# Altium schematic sheet Sheet1.SchDoc(Sheet1)
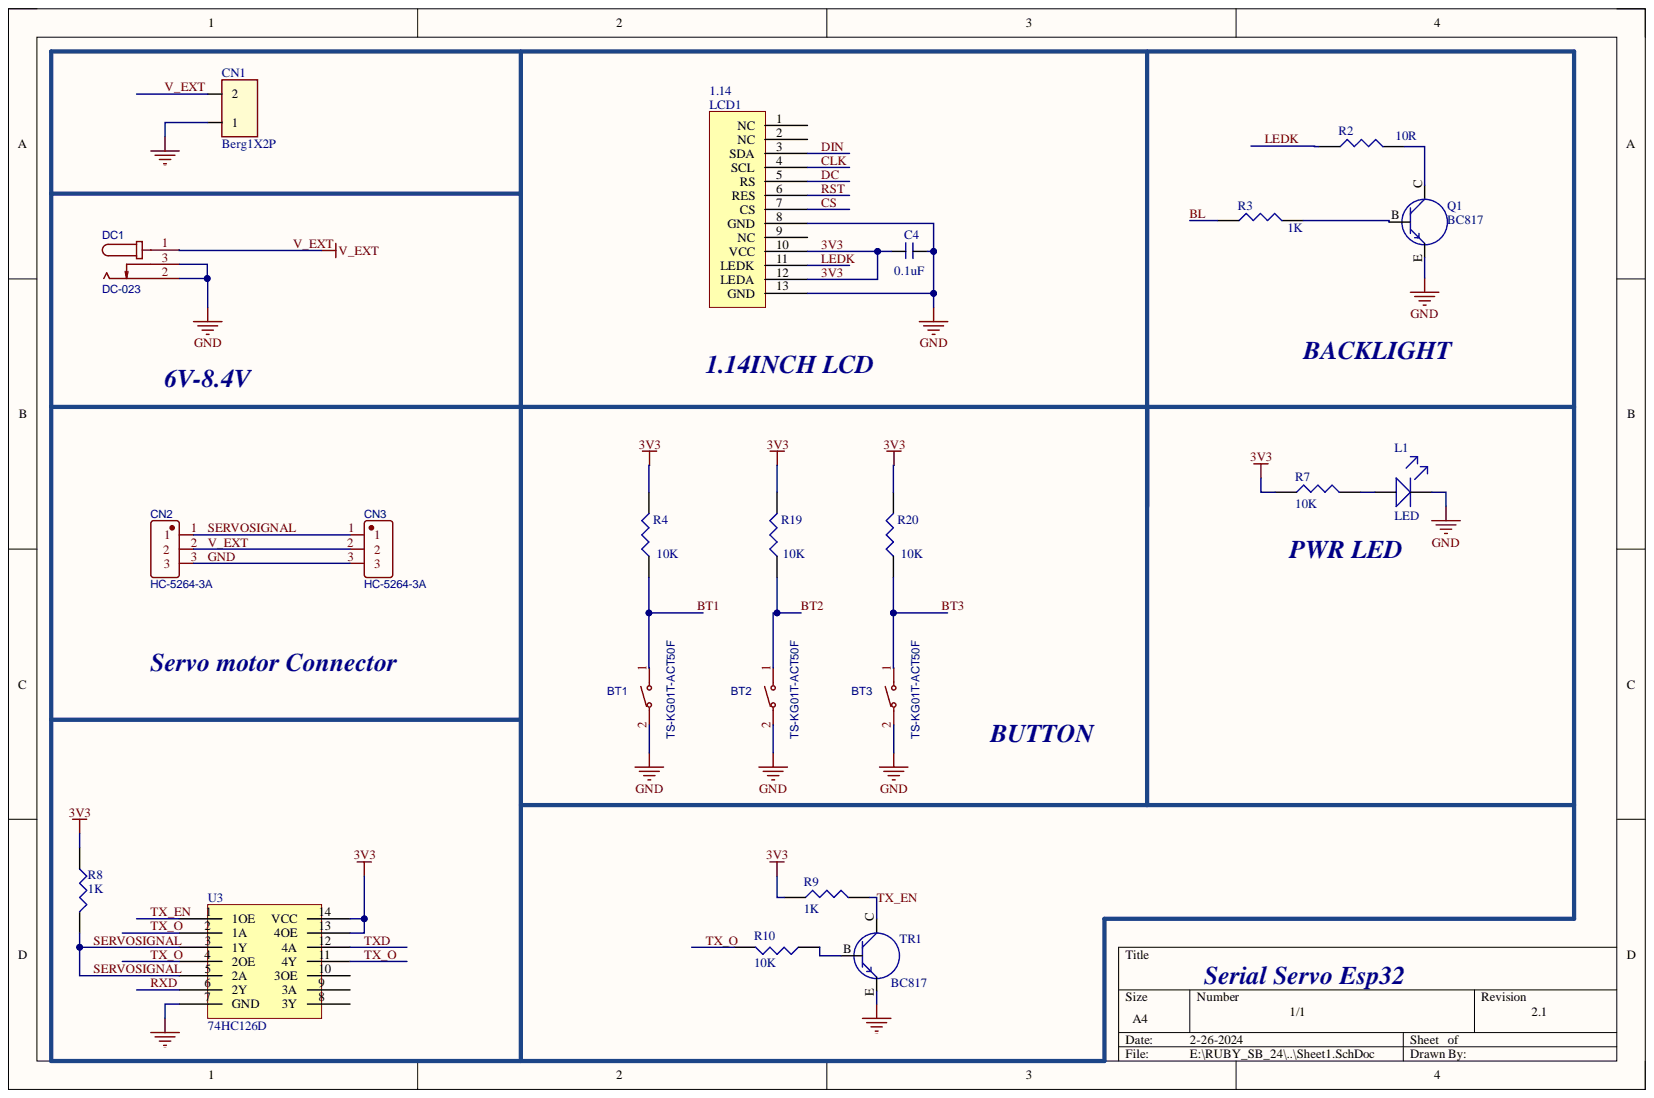
[diagram p0: Sheet1.SchDoc]

## Parts
BT1  TS-KG01T-ACT50F  [SW_2P SMD]
BT2  TS-KG01T-ACT50F  [SW_2P SMD]
BT3  TS-KG01T-ACT50F  [SW_2P SMD]
C4  0.1uF  [C_0603]
CN1  Berg1X2P  [2P_2.54MM]
CN2  HC-5264-3A  [1X3P P-2.5MM SERVO]
CN3  HC-5264-3A  [1X3P P-2.5MM SERVO]
DC1  DC-023  [DC-JACK_DC023]
L1  LED  [LED_0603]
LCD1  1.14  [1.14 inch LCD]
Q1  BC817  [SOT23]
R2  10R  [R_0603]
R3  1K  [R_0603]
R4  10K  [R_0603]
R7  10K  [R_0603]
R8  1K  [0603]
R9  1K  [R_0603]
R10  10K  [R_0603]
R19  10K  [R_0603]
R20  10K  [R_0603]
TR1  BC817  [SOT23]
U3  74HC126D  [SOIC-14]

## Nets
3V3: C4.2 LCD1.10(VCC) LCD1.12(LEDA) R4.2 R7.1 R8.1 R9.1 R19.2 R20.2 U3.13(4OE) U3.14(VCC)
BL: R3.1
BT1: BT1.1 R4.1
BT2: BT2.1 R19.1
BT3: BT3.1 R20.1
CLK: LCD1.4(SCL)
CS: LCD1.7(CS)
DC: LCD1.5(RS)
DIN: LCD1.3(SDA)
GND: BT1.2 BT2.2 BT3.2 C4.1 CN1.1 CN2.3 CN3.3 DC1.2 DC1.3 L1.K LCD1.8(GND) LCD1.13(GND) Q1.E TR1.E U3.7(GND)
LEDK: LCD1.11(LEDK) R2.1
RST: LCD1.6(RES)
RXD: U3.6(2Y)
SERVOSIGNAL: CN2.1 CN3.1 R8.2 U3.3(1Y) U3.5(2A)
TX_EN: R9.2 TR1.C U3.1(1OE)
TX_O: R10.2 U3.2(1A) U3.4(2OE) U3.11(4Y)
TXD: U3.12(4A)
V_EXT: CN1.2 CN2.2 CN3.2 DC1.1
NetL1_A: L1.A R7.2
NetQ1_B: Q1.B R3.2
NetQ1_C: Q1.C R2.2
NetR10_1: R10.1 TR1.B

Not connected: LCD1 1(NC) 2(NC) 9(NC); U3 8(3Y) 9(3A) 10(3OE)

## Library data of the parts
BT1-BT3 = TS-KG01T-ACT50F: BOM_Manufacturer: HANBO(汉博); Contributor: LCSC; BOM_JLCPCB Part Class: Extended Part; BOM_Manufacturer Part: TS-KG01T-ACT50F; BOM_Supplier: LCSC; BOM_Supplier Part: C2874211; Component Kind: Standard; spicePre: S; spiceSymbolName: TS-KG01T-ACT50F
C4 = CAP_NONPOLAR: Component Kind: Standard; Library Name: dxp_pasl_lib.IntLib
CN1 = CON2: Component Kind: Standard; Library Name: dxp_pasl_lib.IntLib
CN2 CN3 = HC-5264-3A: Manufacturer: HCTL(华灿天禄); Contributor: LCSC; Component Kind: Standard; JLCPCB Part Class: Extended Part; Manufacturer Part: HC-5264-3A; spicePre: C; spiceSymbolName: HC-5264-3A; Supplier: LCSC; Supplier Part: C2845815
DC1 = DC_JACK: BOM_Manufacturer: XKB Connectivity(中国星坤); Contributor: LCSC; BOM_JLCPCB Part Class: Extended Part; BOM_Manufacturer Part: DC-023; BOM_Supplier: LCSC; BOM_Supplier Part: C2689666; Component Kind: Standard; Description: DC-023; spicePre: D; spiceSymbolName: DC-023; Library Name: MIX.SchLib
LCD1 = LCD 1.14INCH: 1.14inch LCD Display 65K RGB Colors, 240×135 Pixels, SPI Interface; Component Kind: Standard; Library Name: dxp_pasl_lib.IntLib
Q1 TR1 = TRANSISTER_NPN: Component Kind: Standard; Library Name: dxp_pasl_lib.IntLib
R2-R4 R7-R10 R19 R20 = RES: Component Kind: Standard; Library Name: dxp_pasl_lib.IntLib
L1 = LED: Component Kind: Standard; Library Name: dxp_pasl_lib.IntLib
U3 = 74HC126D: Component Kind: Standard; Library Name: dxp_pasl_lib.IntLib
